# Altium schematic sheet Schematics of MN82 ESP32.SchDoc
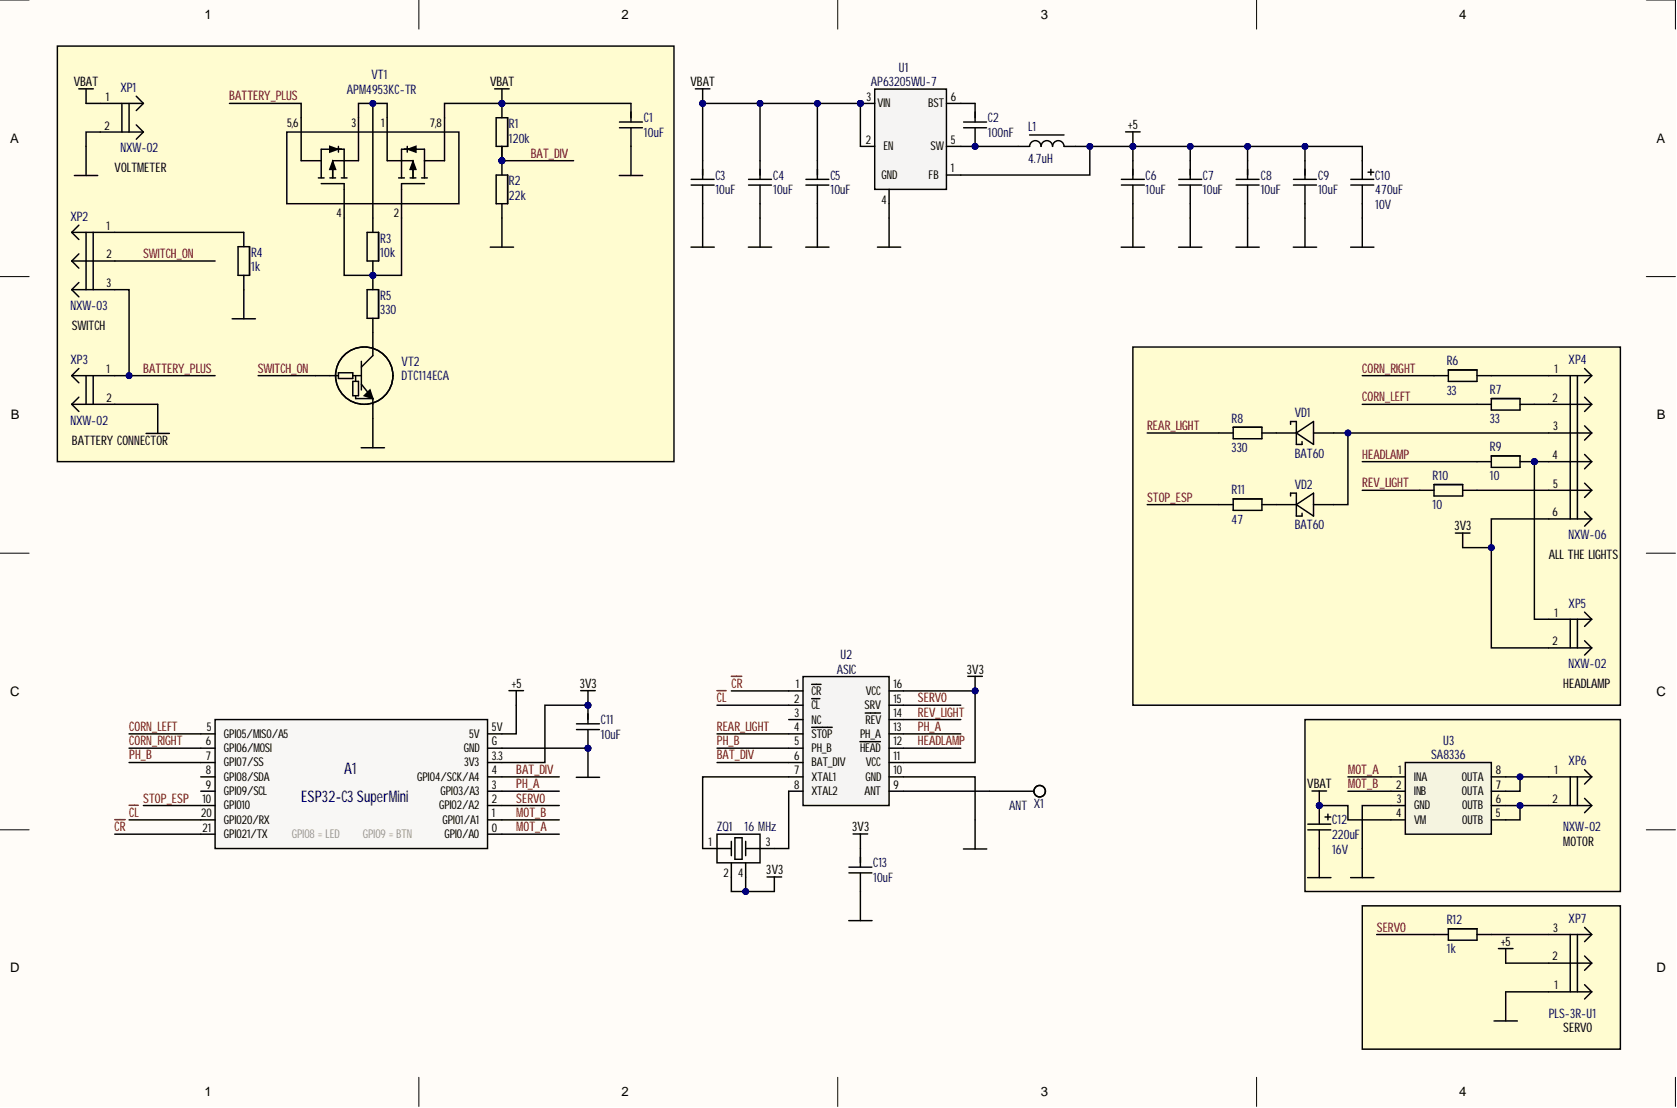
[diagram p0: Schematics of MN82 ESP32.SchDoc]

## Parts
A1  ESP32-C3 SuperMini  [ESP32-C3 SuperMini SMD]
C1  10uF  [C_0805]
C2  100nF  [C_0603]
C3  10uF  [C_1206_LUT]
C4  10uF  [C_1206_LUT]
C5  10uF  [C_1206_LUT]
C6  10uF  [C_0805]
C7  10uF  [C_0805]
C8  10uF  [C_0805]
C9  10uF  [C_0805]
C10  470uF  Voltage Rating: 10V  [EL_D6.3_H11_P2.5]
C11  10uF  [C_0805]
C12  220uF  Voltage Rating: 16V  [EL_D6.3_H11_P2.5]
C13  10uF  [C_0805]
L1  4.7uH  [IHLP2020]
R1  120k  [R_0603]
R2  22k  [R_0603]
R3  10k  [R_0603]
R4  1k  [R_0603]
R5  330  [R_0603]
R6  33  [R_0603]
R7  33  [R_0603]
R8  330  [R_0603]
R9  10  [R_0603]
R10  10  [R_0603]
R11  47  [R_0603]
R12  1k  [R_0603]
U1  AP63205WU-7  [SOT23-6]
U2  ASIC  [SOIC-16]
U3  SA8336  [DIP-8]
VD1  BAT60  [SOD323]
VD2  BAT60  [SOD323]
VT1  APM4953KC-TR  [SOIC-8]
VT2  DTC114ECA  [SOT23]
X1  ANT  [2x0.8p5.08 holes x1]  (no BOM)
XP1  NXW-02  [NXW-02]
XP2  NXW-03  [NXW-03]
XP3  NXW-02  [NXW-02]
XP4  NXW-06  [NXW-06]
XP5  NXW-02  [NXW-02]
XP6  NXW-02  [NXW-02]
XP7  PLS-3R-U1  [PLS-3R-U1]
ZQ1  Frequency: 16 MHz  [Clock_3225]  (hidden comment: ?)

## Nets
3V3: A1.3.3(3V3) C11.1 C13.1 U2.11(VCC) U2.16(VCC) XP4.6 XP5.2 ZQ1.2 ZQ1.4
+5: A1.5V C6.1 C7.1 C8.1 C9.1 C10.1 L1.2 U1.1(FB) XP7.2
GND: A1.G(GND) C1.2 C3.2 C4.2 C5.2 C6.2 C7.2 C8.2 C9.2 C10.2 C11.2 C12.2 C13.2 R2.2 R4.2 U1.4 U2.10(GND) U3.3(GND) VT2.2 XP1.2 XP3.2 XP7.1
VBAT: C1.1 C3.1 C4.1 C5.1 C12.1 R1.1 U1.2(EN) U1.3(VIN) U3.4(VM) VT1.7,8 XP1.1
~{CL}: A1.20(GPIO20/RX) U2.2(CL)
~{CR}: A1.21(GPIO21/TX) U2.1(CR)
BAT_DIV: A1.4(GPIO4/SCK/A4) R1.2 R2.1 U2.6(BAT_DIV)
BATTERY_PLUS: VT1.5,6 XP2.3 XP3.1
CORN_LEFT: A1.5(GPIO5/MISO/A5) R7.1
CORN_RIGHT: A1.6(GPIO6/MOSI) R6.1
HEADLAMP: R9.1 U2.12(HEAD)
MOT_A: A1.0(GPIO/A0) U3.1(INA)
MOT_B: A1.1(GPIO1/A1) U3.2(INB)
PH_A: A1.3(GPIO3/A3) U2.13(PH_A)
PH_B: A1.7(GPIO7/SS) U2.5(PH_B)
REAR_LIGHT: R8.1 U2.4(STOP)
REV_LIGHT: R10.1 U2.14(REV)
SERVO: A1.2(GPIO2/A2) R12.2 U2.15(SRV)
STOP_ESP: A1.10(GPIO10) R11.1
SWITCH_ON: VT2.1 XP2.2
NetC2_1: C2.1 U1.6(BST)
NetC2_2: C2.2 L1.1 U1.5(SW)
NetR3_1: R3.1 VT1.1 VT1.3
NetR3_2: R3.2 R5.1 VT1.2 VT1.4
NetR4_1: R4.1 XP2.1
NetR5_2: R5.2 VT2.3
NetR6_2: R6.2 XP4.1
NetR7_2: R7.2 XP4.2
NetR8_2: R8.2 VD1.K
NetR9_2: R9.2 XP4.4 XP5.1
NetR10_2: R10.2 XP4.5
NetR11_2: R11.2 VD2.K
NetR12_1: R12.1 XP7.3
NetU2_7: U2.7(XTAL1) ZQ1.1
NetU2_8: U2.8(XTAL2) ZQ1.3
NetU2_9: U2.9(ANT) X1.1
NetU3_5: U3.5(OUTB) U3.6(OUTB) XP6.2
NetU3_7: U3.7(OUTA) U3.8(OUTA) XP6.1
NetVD1_A: VD1.A VD2.A XP4.3

Not connected: A1 8(GPIO8/SDA) 9(GPIO9/SCL); U2 3(NC)

## Library data of the parts
A1 = ESP32-C3 SuperMini: Number: 1; Component Kind: Standard; ComponentLink1Description: Datasheet; ComponentLink1URL: https://forum.arduino.cc/uploads/short-url/nOj81CfxGmVM6lPBnpM2slArOc.pdf; ComponentLink2Description: Supplier; ComponentLink2URL: https://arduino.ua/prod6618-mikroplata-esp32-c3-type-c-12-gpio; Library Name: Modules - Arduino.IntLib; Value: ESP32-C3 SuperMini
C1 C6-C9 C11 C13 = Capacitor: Dielectric: X7R; Number: 2; Tolerance: 10%; Voltage Rating: 16V; Component Kind: Standard; ComponentLink1Description: Datasheet; ComponentLink2Description: Supplier; ComponentLink2URL: https://www.kosmodrom.ua/smd-kondensator-1813/chip-keramika-0805-10mkf-x7r-16v-10-2.html; Library Name: Capacitors.IntLib; Size: 0805; Value: 10uF
C2 = Capacitor: Dielectric: X7R; Number: 3; Tolerance: 10%; Voltage Rating: 50V; Component Kind: Standard; ComponentLink1Description: Datasheet; ComponentLink2Description: Supplier; ComponentLink2URL: https://www.kosmodrom.ua/smd-kondensator-1813/chip-keramika-0603-0-1mkf-x7r-50v-10.html; Library Name: Capacitors.IntLib; Size: 0603; Value: 100nF
C3-C5 = Capacitor: Dielectric: X7R; Number: 4; Tolerance: 10%; Voltage Rating: 50V; Component Kind: Standard; ComponentLink1Description: Datasheet; ComponentLink2Description: Supplier; ComponentLink2URL: https://www.kosmodrom.ua/smd-kondensator-1813/chip-keramika-1206-10mkf-x7r-50v-10-2.html; Library Name: Capacitors.IntLib; Size: 1206; Value: 10uF
C10 = Capacitor_polar: Dielectric: Electrolytic; Number: 5; Tolerance: 20%; Component Kind: Standard; ComponentLink1Description: Datasheet; ComponentLink2Description: Supplier; ComponentLink2URL: REUSE; Library Name: Capacitors.IntLib; Size: 6.3*11*2.5; Value: 470uF
C12 = Capacitor_polar: Dielectric: Electrolytic; Number: 6; Tolerance: 20%; Component Kind: Standard; ComponentLink1Description: Datasheet; ComponentLink2Description: Supplier; ComponentLink2URL: REUSE; Library Name: Capacitors.IntLib; Size: 6.3*11*2.5; Value: 220uF
L1 = Inductor: Part Number: IHLP2020CZER4R7M8A; Number: 7; Tolerance: 20%; Component Kind: Standard; ComponentLink1Description: Datasheet; ComponentLink2Description: Supplier; ComponentLink2URL: https://www.kosmodrom.ua/drosel/drossel-smd0520-4-7-mkgn-2.html; Library Name: Inductors.IntLib; Size: 2020; Value: 4.7uH
R1 = Resistor: Number: 8; Tolerance: 5%; Component Kind: Standard; ComponentLink1Description: Datasheet; ComponentLink2Description: Supplier; ComponentLink2URL: REUSE; Library Name: Resistors.IntLib; Size: 0603; Value: 120k
R2 = Resistor: Number: 9; Tolerance: 5%; Component Kind: Standard; ComponentLink1Description: Datasheet; ComponentLink2Description: Supplier; ComponentLink2URL: REUSE; Library Name: Resistors.IntLib; Size: 0603; Value: 22k
R3 = Resistor: Number: 10; Tolerance: 5%; Component Kind: Standard; ComponentLink1Description: Datasheet; ComponentLink2Description: Supplier; ComponentLink2URL: https://www.kosmodrom.ua/smd-rezistor-2513/smd-rezistor-0603-10-kom-1-tochni.html; Library Name: Resistors.IntLib; Size: 0603; Value: 10k
R4 R12 = Resistor: Number: 11; Tolerance: 5%; Component Kind: Standard; ComponentLink1Description: Datasheet; ComponentLink2Description: Supplier; ComponentLink2URL: REUSE; Library Name: Resistors.IntLib; Size: 0603; Value: 1k
R5 R8 = Resistor: Number: 12; Tolerance: 5%; Component Kind: Standard; ComponentLink1Description: Datasheet; ComponentLink2Description: Supplier; Library Name: Resistors.IntLib; Size: 0603; Value: 330
  R5: ComponentLink2URL: https://www.kosmodrom.ua/smd-rezistor-2513/smd-rezistor-0603-330-om-5.html
  R8: ComponentLink2URL: REUSE
R6 R7 = Resistor: Number: 13; Tolerance: 5%; Component Kind: Standard; ComponentLink1Description: Datasheet; ComponentLink2Description: Supplier; ComponentLink2URL: REUSE; Library Name: Resistors.IntLib; Size: 0603; Value: 33
R9 R10 = Resistor: Number: 14; Tolerance: 5%; Component Kind: Standard; ComponentLink1Description: Datasheet; ComponentLink2Description: Supplier; ComponentLink2URL: REUSE; Library Name: Resistors.IntLib; Size: 0603; Value: 10
R11 = Resistor: Number: 15; Tolerance: 5%; Component Kind: Standard; ComponentLink1Description: Datasheet; ComponentLink2Description: Supplier; ComponentLink2URL: https://www.kosmodrom.ua/smd-rezistor-2513/smd-rezistor-0603-47-om-5.html; Library Name: Resistors.IntLib; Size: 0603; Value: 47
U1 = AP63205WU-7: Number: 16; Component Kind: Standard; ComponentLink1Description: Datasheet; ComponentLink1URL: https://eu.mouser.com/datasheet/2/115/DIOD_S_A0007089856_1-2542907.pdf; ComponentLink2Description: Supplier; ComponentLink2URL: https://www.kosmodrom.ua/dc-dc-peretvoryuvach-integralniy/ap63205wu-7.html; Library Name: IC - Power.IntLib; Part Number: AP63205WU-7; Size: SOT23-6; Value: AP63205WU-7
U2 = 16-PIN: Number: 17; Component Kind: Standard; ComponentLink1Description: Datasheet; ComponentLink1URL: N/A; ComponentLink2Description: Supplier; ComponentLink2URL: REUSE; Size: SOIC-16; Value: ASIC; Library Name: IC - Templates.IntLib
U3 = 8-PIN: Number: 18; Component Kind: Standard; ComponentLink1Description: Datasheet; ComponentLink1URL: https://www.sytatek.com/uploads/soft/SA8336-CN-V1.2.pdf; ComponentLink2Description: Supplier; ComponentLink2URL: REUSE; Size: DIP-8; Value: SA8336; Library Name: IC - Templates.IntLib
VD1 VD2 = Diode_Schottky: Number: 19; Component Kind: Standard; ComponentLink1Description: Datasheet; ComponentLink1URL: https://www.st.com/en/diodes-and-rectifiers/bat60.html; ComponentLink2Description: Supplier; ComponentLink2URL: https://www.kosmodrom.ua/diod-shottki-2/bat60jfilm.html; Library Name: Diodes.IntLib; Part Number: BAT60; Size: SOD323; Value: BAT60
VT1 = APM4953KC-TR: Number: 20; Structure: 2 P-ch; Component Kind: Standard; ComponentLink1Description: Datasheet; ComponentLink1URL: https://pdf.voron.ua/files/pdf/tranzistor/APM4953KC-TR.pdf; ComponentLink2Description: Supplier; ComponentLink2URL: https://voron.ua/uk/catalog/034298--tranzistor_apm4953kc-tr_so8_so8; Library Name: Transistors.IntLib; Size: SOIC-8; Value: APM4953KC-TR
VT2 = DTC114ECA: Number: 21; Structure: Digital n-p-n; Component Kind: Standard; ComponentLink1Description: Datasheet; ComponentLink1URL: https://pdf.voron.ua/files/pdf/CJ/Transistors/DTC114ECA.pdf; ComponentLink2Description: Supplier; ComponentLink2URL: https://voron.ua/uk/catalog/029372--tranzistor_dtc114eca_cj_jiangsu_changjiang_electronics_technology_co_ltd_sot23_s; Library Name: Transistors.IntLib; Part Number: DTC114ECA; Size: SOT23; Value: DTC114ECA
X1 = Solder x1: Number: 22; ComponentLink2Description: Supplier; ComponentLink2URL: REUSE; Library Name: Connectors - Holes and Pads.IntLib; Value: ANT
XP1 XP3 XP5 XP6 = NXW-02: Number: 23; Component Kind: Standard; ComponentLink1Description: Datasheet; ComponentLink2Description: Supplier; Library Name: Connectors - NXW PH.IntLib; Value: NXW-02
  XP1: ComponentLink2URL: https://www.kosmodrom.ua/roznimannya-signalni-nx-z-krokom-2-mm/nxw-02.html
  XP3 XP5 XP6: ComponentLink2URL: REUSE
XP2 = NXW-03: Number: 24; Component Kind: Standard; ComponentLink1Description: Datasheet; ComponentLink2Description: Supplier; ComponentLink2URL: REUSE; Library Name: Connectors - NXW PH.IntLib; Value: NXW-03
XP4 = NXW-06: Number: 25; Component Kind: Standard; ComponentLink1Description: Datasheet; ComponentLink2Description: Supplier; ComponentLink2URL: REUSE; Library Name: Connectors - NXW PH.IntLib; Value: NXW-06
XP7 = PLS-3R-U1: Number: 26; Component Kind: Standard; ComponentLink1Description: Datasheet; ComponentLink2Description: Supplier; ComponentLink2URL: REUSE; Library Name: Connectors - PLS.IntLib; Value: PLS-3R-U1
ZQ1 = Crystal_oscillator_4_pin: Number: 27; Component Kind: Standard; ComponentLink1Description: Datasheet; ComponentLink2Description: Supplier; ComponentLink2URL: REUSE; Library Name: Crystals.IntLib; Size: 3225; Value: ?
